# Altium schematic sheet TPS54202.SchDoc(TPS54202)
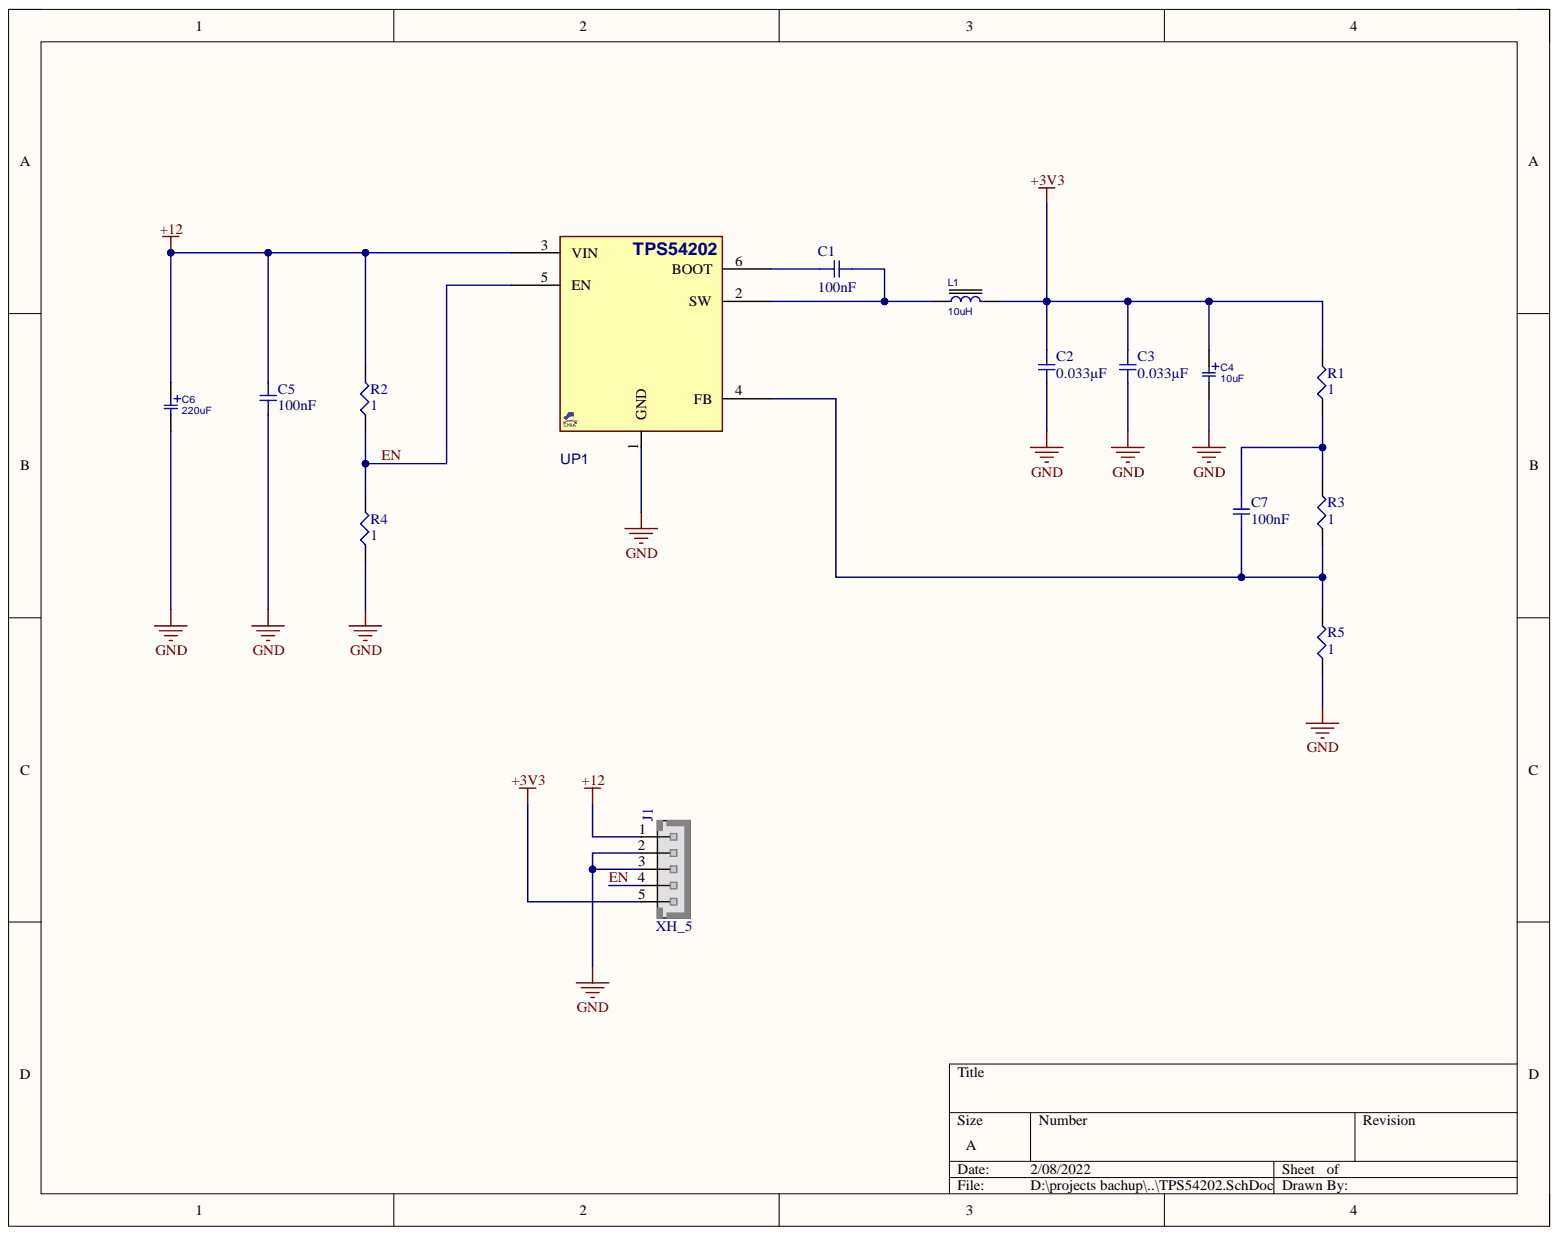
[diagram p0: TPS54202.SchDoc]

## Parts
C1  100nF  [SMD-0805C]
C2  0.033µF  [SMD-1206C]
C3  0.033µF  [SMD-1206C]
C4  10uF  [C_TN_3216]
C5  100nF  [SMD-0805C]
C6  220uF  [C_TN_7343]
C7  100nF  [SMD-0805C]
J1  XH_5  [XH_5]
L1  10uH  [F1812]
R1  1  [SMD-0805-RES]
R2  1  [SMD-0805-RES]
R3  1  [SMD-0805-RES]
R4  1  [SMD-0805-RES]
R5  1  [SMD-0805-RES]
UP1  TPS54202  [SOT23_6]

## Nets
+3V3: C2.1 C3.1 C4.1 J1.5 L1.2 R1.2
+12: C5.2 C6.1 J1.1 R2.2 UP1.3(VIN)
GND: C2.2 C3.2 C4.2 C5.1 C6.2 J1.2 J1.3 R4.1 R5.1 UP1.1(GND)
EN: J1.4 R2.1 R4.2 UP1.5(EN)
NetC1_1: C1.1 UP1.6(BOOT)
NetC1_2: C1.2 L1.1 UP1.2(SW)
NetC7_1: C7.1 R3.1 R5.2 UP1.4(FB)
NetC7_2: C7.2 R1.1 R3.2

## Library data of the parts
C1 C5 C7 = GC321AD72E103KX01D: CAP CER 100nF 50V X7T 0805; Manufacturer: Murata Electronics North America; @ qty: 0; Minimum Quantity: 1; Tolerance: ±10%; Unit Price (USD): 0.3; Value: 10000pF; Voltage: 250V; Applications: Automotive; Component Kind: Standard; Datasheets: http://search.murata.co.jp/Ceramy/image/img/A01X/G101/ENG/GC321AD72E103KX01-01.pdf; Digi-Key Part Number: 490-13986-1-ND; Factory Stock: 0; Features: -; Height - Seated (Max): -; Image: //media.digikey.com/Renders/Murata%20Renders/0805(GC3)200x125.jpg; Lead Spacing: -; Lead Style: -; Library Name: capacitor_murata_0805.IntLib; Mounting Type: Surface Mount, MLCC; Operating Temperature: -55°C ~ 125°C; Package / Case: 0805 (2012 Metric); Packaging: Cut Tape (CT); Part Number: GC321AD72E103KX01D; Part Status: Active; Quantity Available: 4000; Ratings: AEC-Q200; Series: GC3; Size / Dimension: 0.079" L x 0.049" W (2.00mm x 1.25mm); Temperature Coefficient: X7T; Thickness (Max): 0.039" (1.00mm)
C2 C3 = GC331AD72E333KX01D: CAP CER 0.033UF 250V X7T 1206; Manufacturer: Murata Electronics North America; @ qty: 0; Minimum Quantity: 1; Tolerance: ±10%; Unit Price (USD): 0.33; Applications: Automotive; Component Kind: Standard; Datasheets: http://search.murata.co.jp/Ceramy/image/img/A01X/G101/ENG/GC331AD72E333KX01-01.pdf; Digi-Key Part Number: 490-13989-1-ND; Factory Stock: 0; Features: -; Height - Seated (Max): -; Image: //media.digikey.com/Renders/Murata%20Renders/1206(GC3)320x160.jpg; Lead Spacing: -; Lead Style: -; Library Name: capacitor_murata_1206.IntLib; Mounting Type: Surface Mount, MLCC; Operating Temperature: -55°C ~ 125°C; Package / Case: 1206 (3216 Metric); Packaging: Cut Tape (CT); Part Number: GC331AD72E333KX01D; Part Status: Active; Quantity Available: 3999; Ratings: AEC-Q200; Series: GC3; Size / Dimension: 0.126" L x 0.063" W (3.20mm x 1.60mm); Temperature Coefficient: X7T; Thickness (Max): 0.039" (1.00mm); Value: 0.033µF; Voltage: 250V
C4 = CAP_SMD_TAN_10uF_6.3V: CAP, SMD, TAN; MANF: VISHAY; MANF_P#: T55A106M6R3C0300; PARAM: 20%, 300mOhm, -55~105oC; PARAM2: 6.3V; Component Kind: Standard; PRICE: 0.46$; VALUE: 10uF; Library Name: chila .IntLib
C6 = CAP_SMD_TAN_220uF: CAP, SMD, TAN; MANF: VISHAY; MANF_P#: T55D227M6R3C0035; PARAM: 20%, 35mOhm, -55~105oC; PARAM2: 6.3V; Component Kind: Standard; PRICE: 1.9$; VALUE: 220uF; Library Name: chila .IntLib
J1 = XH_5: JST-XH pitch: 2.5mm; MANF: JST; MANF_P#: JST-B5B-XHA; Component Kind: Standard; Signal Integrity: Connector; VALUE: XH_5; Library Name: chila .IntLib
L1 = IND_SMD_10uH_2A: INDUCTOR, 10uH 2A, 38mOhm, 2626; MANF: Bourns; MANF_P#: SRR6038-100Y; PARAM: 2A Irms, 2.05A Isat; ASSEMBLY: ASSEMBLY; Component Kind: Standard; DESC: INDUCTOR, 10uH 2A, 38mOhm, 2626; PARAM2: 38mOhm; PRICE: 2.5$; VALUE: 10uH; Library Name: chila .IntLib
R1-R5 = ERJ-6BQF1R0V: RES SMD 1 OHM 1% 1/3W 0805; Manufacturer: Panasonic Electronic Components; @ qty: 1500; Factory Stock: 0; Power (Watts): 0.333W, 1/3W; Temperature Coefficient: ±200ppm/°C; Unit Price (USD): 0.05834; Component Kind: Standard; Composition: Thick Film; Datasheets: https://industrial.panasonic.com/cdbs/www-data/pdf/RDA0000/AOA0000C239.pdf; Digi-Key Part Number: P17499CT-ND; Failure Rate: -; Features: Automotive AEC-Q200, Current Sense; Height - Seated (Max): 0.028" (0.70mm); Image: //media.digikey.com/Renders/Panasonic%20Renders/ERJ-0805%20Pkg.jpg; Library Name: Panasonic_ERJ_0805.IntLib; Minimum Quantity: 1; Number of Terminations: 2; Operating Temperature: -55°C ~ 125°C; Package / Case: 0805 (2012 Metric); Packaging: Cut Tape (CT); Part Number: ERJ-6BQF1R0V; Part Status: Active; Quantity Available: 808; Series: ERJ; Size / Dimension: 0.079" L x 0.049" W (2.00mm x 1.25mm); Supplier Device Package: 0805; Tolerance: ±1%; Value: 1
UP1 = VREG_TPS54202_SOT23-6: REG, Switching, Buck, 2A, ADJ, 0.5MHz, 4.5~28VIN, SOT23-6; MANF: TI; MANF_P#: TPS54202DDC, TPS54202HDDC; PARAM: 2A, 0.5MHz, -40~125oC; PARAM2: 4.5~28VIN, ADJ, 148mΩ RDSon; ASSEMBLY: ASSEMBLY; Component Kind: Standard; PRICE: 2200t@LION; VALUE: TPS54202; Library Name: chila .IntLib
